# Altium schematic sheet Input_Connectors.SchDoc
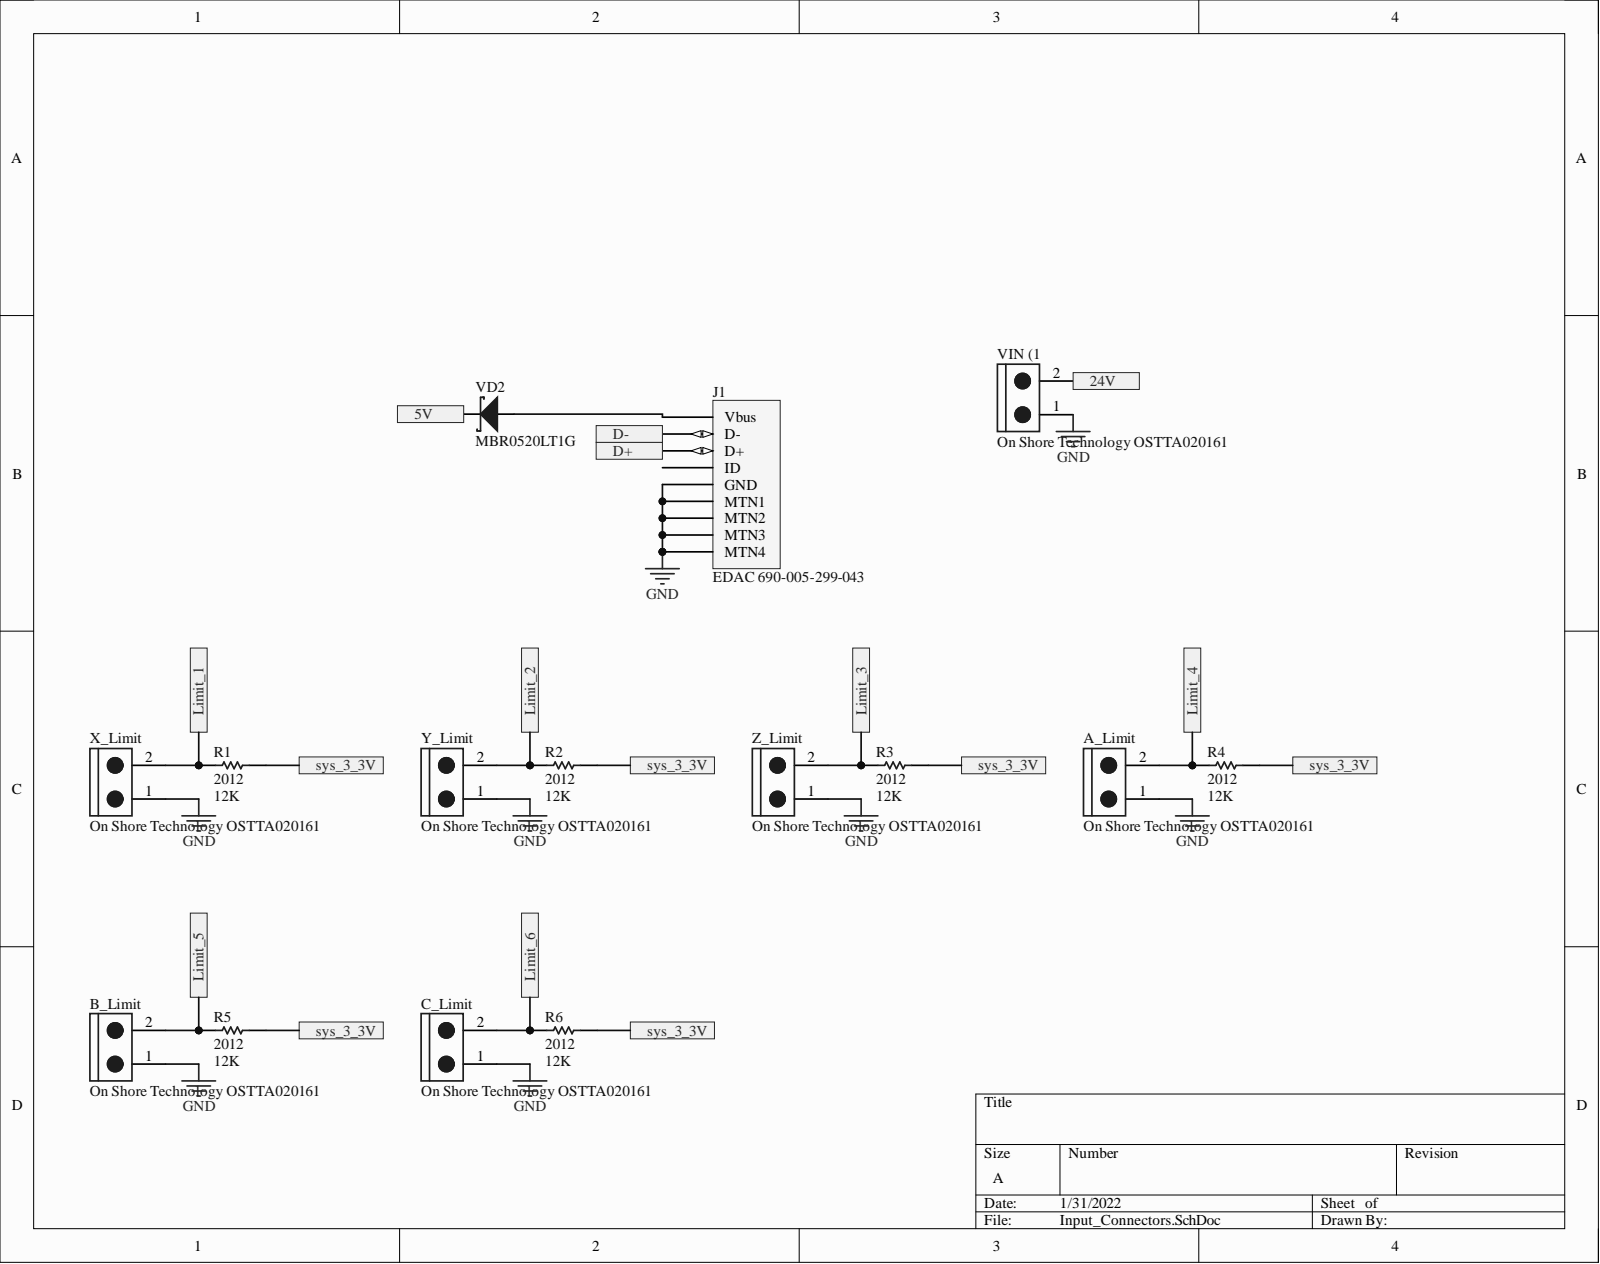
[diagram p0: Input_Connectors.SchDoc]

## Parts
A_Limit  On Shore Technology OSTTA020161  [PCBComponent_1 - duplicate]
B_Limit  On Shore Technology OSTTA020161  [PCBComponent_1 - duplicate]
C_Limit  On Shore Technology OSTTA020161  [PCBComponent_1 - duplicate]
J1  EDAC 690-005-299-043  Manufacturer Part Number: 690-005-299-043  [PCB-0dqtvzwtjwndkeioqta0-1]
R1  Case/Package (SI): 2012  Resistance: 12K  [RESC2013X70X40ML20T20]  (hidden comment: Panasonic ERJ-6GEYJ822V)
R2  Case/Package (SI): 2012  Resistance: 12K  [RESC2013X70X40ML20T20]  (hidden comment: Panasonic ERJ-6GEYJ822V)
R3  Case/Package (SI): 2012  Resistance: 12K  [RESC2013X70X40ML20T20]  (hidden comment: Panasonic ERJ-6GEYJ822V)
R4  Case/Package (SI): 2012  Resistance: 12K  [RESC2013X70X40ML20T20]  (hidden comment: Panasonic ERJ-6GEYJ822V)
R5  Case/Package (SI): 2012  Resistance: 12K  [RESC2013X70X40ML20T20]  (hidden comment: Panasonic ERJ-6GEYJ822V)
R6  Case/Package (SI): 2012  Resistance: 12K  [RESC2013X70X40ML20T20]  (hidden comment: Panasonic ERJ-6GEYJ822V)
VD2  MBR0520LT1G  [SOD-123_B]
VIN_(1  On Shore Technology OSTTA020161  [PCBComponent_1 - duplicate]
X_Limit  On Shore Technology OSTTA020161  [PCBComponent_1 - duplicate]
Y_Limit  On Shore Technology OSTTA020161  [PCBComponent_1 - duplicate]
Z_Limit  On Shore Technology OSTTA020161  [PCBComponent_1 - duplicate]

## Ports
5V, 24V, D+, D-, Limit_1, Limit_2, Limit_3, Limit_4, Limit_5, Limit_6, sys_3_3V

## Nets
GND: A_Limit.1 B_Limit.1 C_Limit.1 J1.GND J1.MTN1 J1.MTN2 J1.MTN3 J1.MTN4 VIN_(1.1 X_Limit.1 Y_Limit.1 Z_Limit.1
5V: VD2.1 ; port 5V
24V: VIN_(1.2 ; port 24V
D+: J1.D+ ; port D+
D-: J1.D- ; port D-
Limit_1: R1.2 X_Limit.2 ; port Limit_1
Limit_2: R2.2 Y_Limit.2 ; port Limit_2
Limit_3: R3.2 Z_Limit.2 ; port Limit_3
Limit_4: A_Limit.2 R4.2 ; port Limit_4
Limit_5: B_Limit.2 R5.2 ; port Limit_5
Limit_6: C_Limit.2 R6.2 ; port Limit_6
sys_3_3V: R1.1 R2.1 R3.1 R4.1 R5.1 R6.1 ; port sys_3_3V
NetJ1_Vbus: J1.Vbus VD2.2

Not connected: J1 ID

## Library data of the parts
A_Limit B_Limit C_Limit VIN_(1 X_Limit Y_Limit Z_Limit = CMP-2d54e45ab247f293-4: Connector Terminal Blocks 2 Position 5mm Solder Straight Thru-Hole 16A; Pin Pitch: 5.00 mm; Component Kind: Standard; Flammability Rating: UL 94 V-0; Housing Color: Blue; Housing Material: Nylon; Library Name: CommunityVault; Manufacturer Part Number: OSTTA020161; Number of Rows: 1; Octopart URL: http://octopart.com/ostta020161-on+shore+technology-309877; Size-Height: 12.6 mm
J1 = CMP-f10139da97e32ec6-8: Conn Mini Usb Rcpt Ra Type b Smd; Manufacturer: EDAC Inc.; Connector Type: USB - mini B; Gender: Receptacle; Mating Cycles: 1500; Component Kind: Standard; Library Name: CommunityVault; Mounting Type: Surface Mount, Right Angle; Octopart Url: https://octopart.com/search?q=690-005-299-043&currency=USD&specs=0
R1-R6 = CMP-3687578-2: Thick Film Resistors - SMD 0805 8.2Kohms 5% Tol; Lead-Free Status: Lead Free; Power Rating: 0.125 W; Voltage Rating (DC): 150.0 V; Case/Package: 0805; Component Kind: Standard; Library Name: CommunityVault; Lifecycle Status: Not Listed by Manufacturer; Manufacturer Part Number: ERJ-6GEYJ822V; Mounting Style: Surface Mount; Octopart Url: https://octopart.com/erj-6geyj822v-panasonic-55423066; OctopartID: 0556cf11906ada91; Packaging: Cut Tape (CT); Resistance Tolerance: ±5%; RoHS: Compliant; Size-Height: 0.0006 m; Size-Length: 0.002 m; Size-Width: 0.00125 m
VD2 = CMP-3786467-11: Rectifier, Schottky Power; Plastic Sod-123; 0.5 a @ +90c If; +125; 20v; 1000; Manufacturer Part Number: ‎SBR80520LT1G; Current Rating: 0.5 A; Forward Voltage: 0.385 V; Lead-Free Status: Lead Free; Polarity: Standard; Voltage Rating (DC): 20.0 V; Case/Package: SOD-123; Component Kind: Standard; Library Name: CommunityVault; Lifecycle Status: Active; Mounting Style: Surface Mount; Number of Pins: 2; Octopart Url: http://octopart.com/mbr0520lt1g-on+semiconductor-2933; OctopartID: 81934899af805e54; REACH SVHC Compliance: No SVHC; RoHS: Compliant; Seller Landing  Url: https://octopart-clicks.com/click/track?country=US&ak=8157f166&sig=02b8313&sid=5489&ppid=2933&vpid=120602749&ct=offers
